# Altium schematic sheet BPSDSchematic.SchDoc
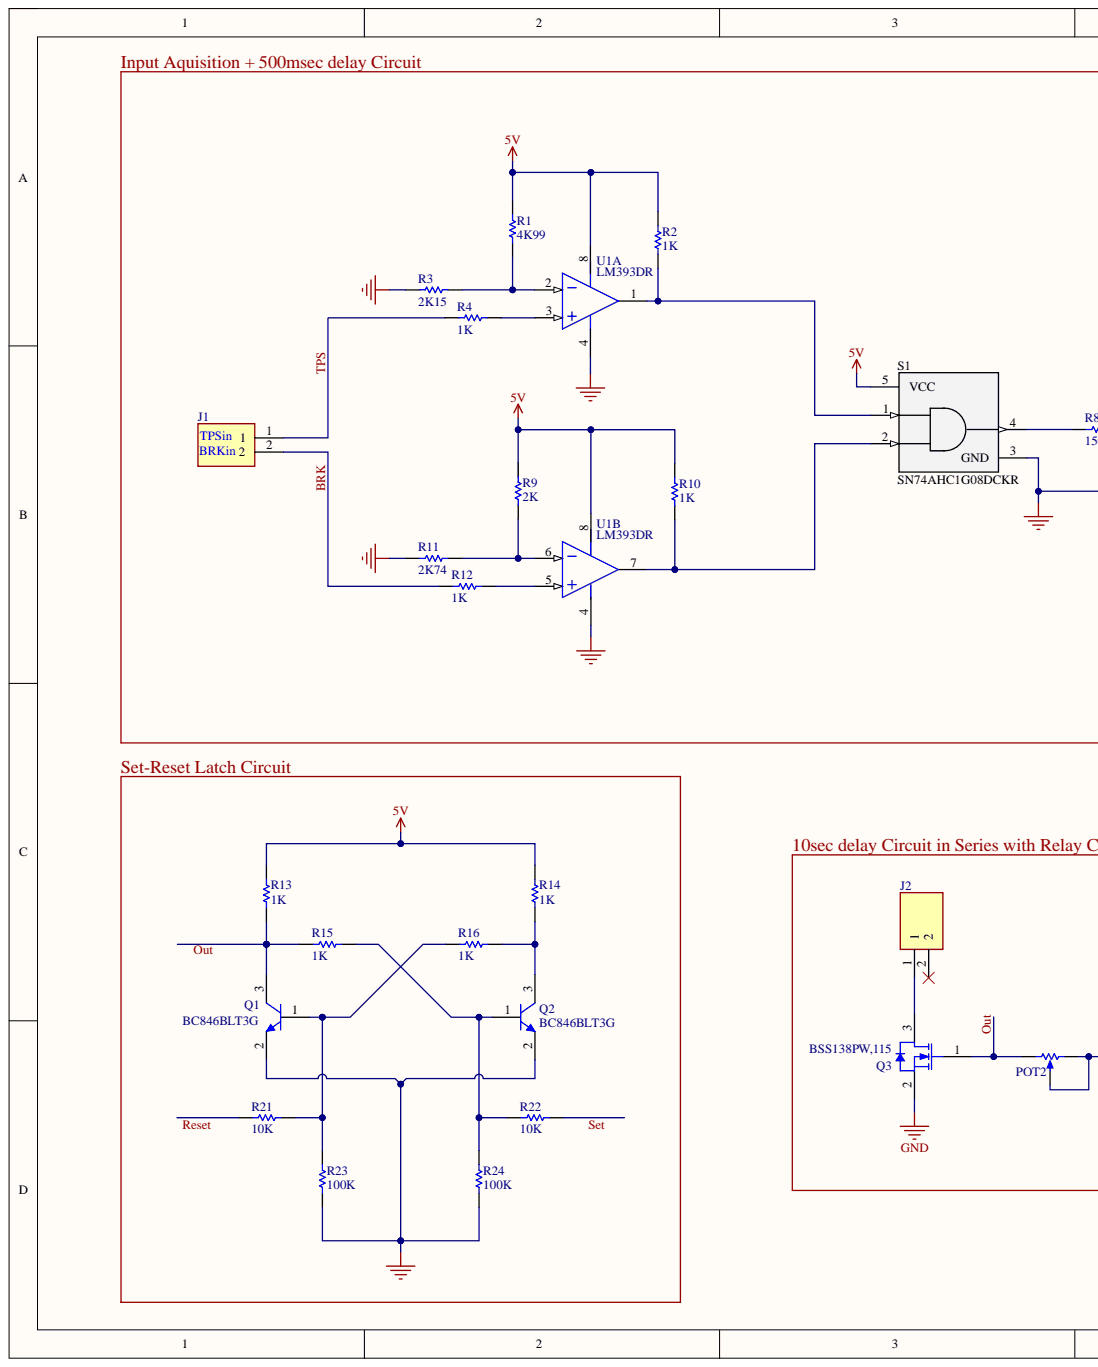
[diagram p0: BPSDSchematic.SchDoc, left]
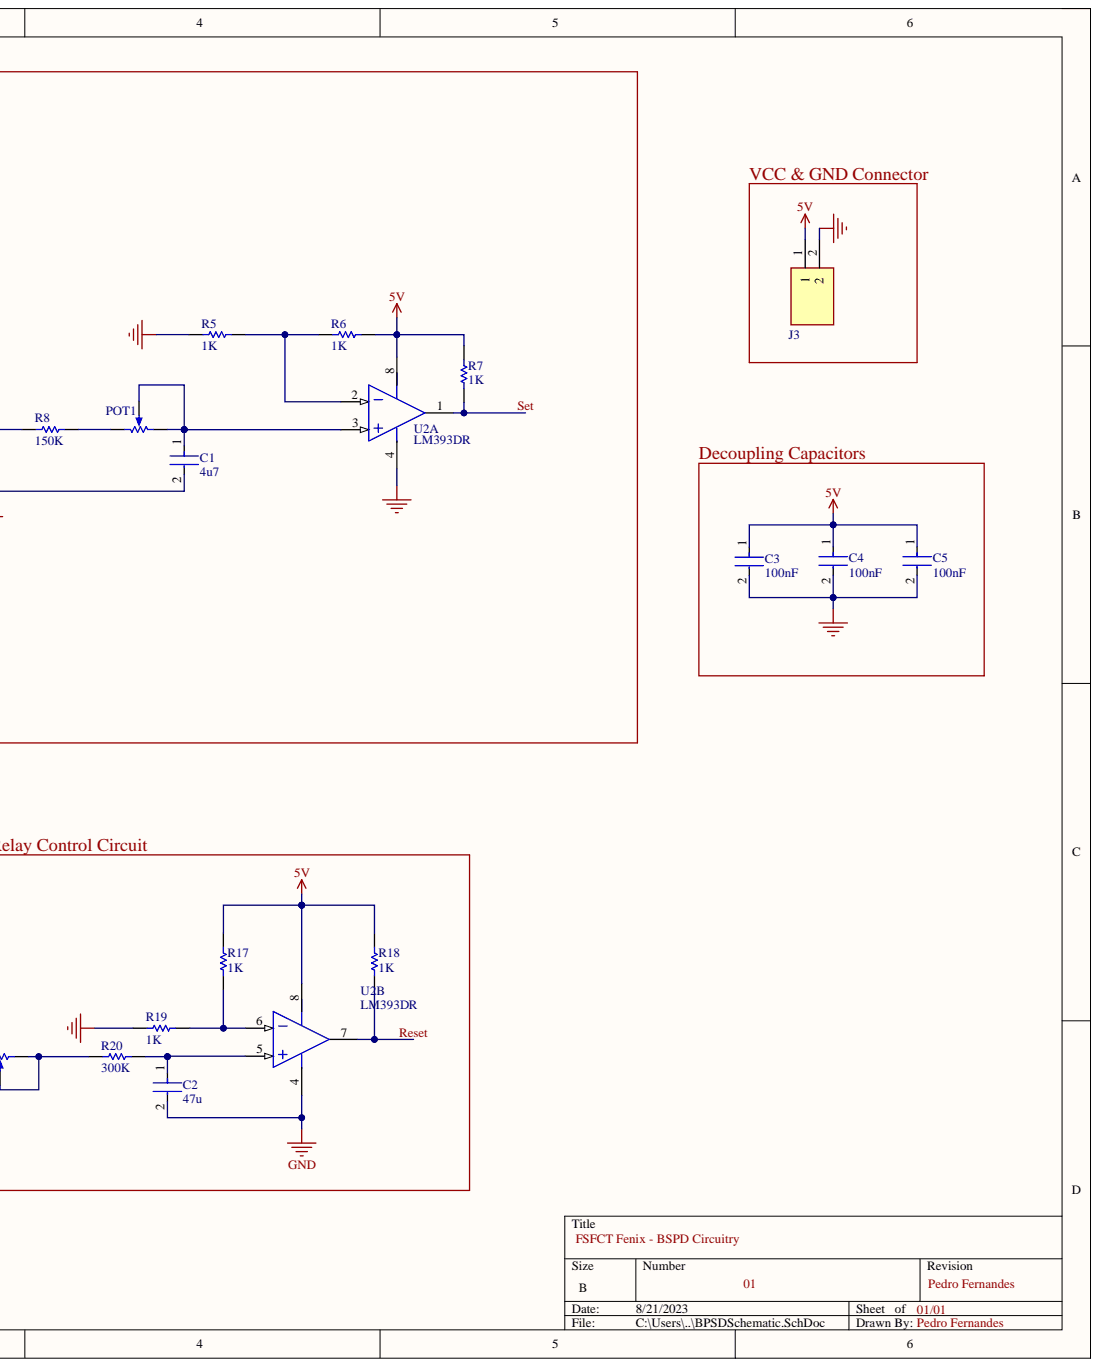
[diagram p1: BPSDSchematic.SchDoc, right]

## Parts
C1  4u7  [FP-CL10-IPC_C]
C2  47u  [CL32B476KQVVPNE]
C3  100nF  [FP-CL94-IPC_C]
C4  100nF  [FP-CL94-IPC_C]
C5  100nF  [FP-CL94-IPC_C]
J1  640445-2  [640445-2]  (value not drawn)
J2  640445-2  [640445-2]  (value not drawn)
J3  640445-2  [640445-2]  (value not drawn)
POT1  3362P-1-103LF  [BOUR-3362P]  (value not drawn)
POT2  3362P-1-103LF  [BOUR-3362P]  (value not drawn)
Q1  BC846BLT3G  [ONSC-SOT-23-3-318-08_V]
Q2  BC846BLT3G  [ONSC-SOT-23-3-318-08_V]
Q3  BSS138PW,115  [NXP-SOT323_M]
R1  4K99  [RC0603FR-074K99L]
R2  1K  [RC0603FR-071KL]
R3  2K15  [RESC0603(1608)_M]
R4  1K  [RC0603FR-071KL]
R5  1K  [RC0603FR-071KL]
R6  1K  [RC0603FR-071KL]
R7  1K  [RC0603FR-071KL]
R8  150K  [RC0603FR-07150KL]
R9  2K  [RC0603FR-072KL]
R10  1K  [RC0603FR-071KL]
R11  2K74  [RESC0603(1608)_N]
R12  1K  [RC0603FR-071KL]
R13  1K  [RC0603FR-071KL]
R14  1K  [RC0603FR-071KL]
R15  1K  [RC0603FR-071KL]
R16  1K  [RC0603FR-071KL]
R17  1K  [RC0603FR-071KL]
R18  1K  [RC0603FR-071KL]
R19  1K  [RC0603FR-071KL]
R20  300K  [RESC0603(1608)_M]
R21  10K  [RC0603FR-0710KL]
R22  10K  [RC0603FR-0710KL]
R23  100K  [RC0603FR-07100KL]
R24  100K  [RC0603FR-07100KL]
S1  SN74AHC1G08DCKR  [FP-DCK0005A-MFG]
U1  LM393DR  [LM393DR]  (parts A,B)
U2  LM393DR  [LM393DR]  (parts A,B)

## Nets
5V: C3.1 C4.1 C5.1 J3.1 R1.2 R2.2 R6.2 R7.2 R9.2 R10.2 R13.2 R14.2 R17.1 R18.1 S1.5(VCC) U1.8 U2.8
GND: C1.2 C2.2 C3.2 C4.2 C5.2 J3.2 Q1.2 Q2.2 Q3.2 R3.1 R5.1 R11.1 R19.1 R23.1 R24.1 S1.3(GND) U1.4 U2.4
BRK: J1.2 R12.1
Out: POT2.1 Q3.1
Reset: R18.2 R21.1 U2.7
Set: R7.1 R22.2 U2.1
TPS: J1.1 R4.1
NetC1_1: C1.1 POT1.2 POT1.3 U2.3
NetC2_1: C2.1 R20.2 U2.5
NetJ2_1: J2.1 Q3.3
NetPOT1_1: POT1.1 R8.2
NetPOT2_2: POT2.2 POT2.3 R20.1
NetQ1_1: Q1.1 R16.1 R21.2 R23.2
NetQ1_3: Q1.3 R13.1 R15.1
NetQ2_1: Q2.1 R15.2 R22.1 R24.2
NetQ2_3: Q2.3 R14.1 R16.2
NetR1_1: R1.1 R3.2 U1.2
NetR2_1: R2.1 S1.1 U1.1
NetR4_2: R4.2 U1.3
NetR5_2: R5.2 R6.1 U2.2
NetR8_1: R8.1 S1.4
NetR9_1: R9.1 R11.2 U1.6
NetR10_1: R10.1 S1.2 U1.7
NetR12_2: R12.2 U1.5
NetR17_2: R17.2 R19.2 U2.6

Not connected: J2 2

## Library data of the parts
C1 = CMP-2000-06096-2: CAP CER 4.7UF 6.3V X7R 0603; Manufacturer: Samsung; Manufacturer Part Number: CL10B475KQ8NQNC; Material: Ceramic; Temperature Coefficient: 15%; Tolerance: 10%; Automotive: No; Capacitance: 4.7uF; Category: Cap; Component Kind: Standard; ComponentLink2Description: Datasheet; ComponentLink2URL: http://www.samsungsem.com/kr/support/product-search/mlcc/__icsFiles/afieldfile/2018/07/24/CL10B475KQ8NQNC.pdf; Device Class L1: Passive Components; Device Class L2: Capacitors; Device Class L3: Ceramic Capacitors; Digikey Description: CAP CER 4.7UF 6.3V X7R 0603; Height: 0.95mm; Ipc Land Pattern Name: CAPC16080X80; Lead Free: Yes; Library Name: Altium Content Vault; Mouser Description: C Series 0402 4.7 uF 6.3 V ±20 % Tolerance X5R SMT Multilayer Ceramic Capacitor; Octopart: https://octopart.com/cl10b475kq8nqnc-samsung-24957976; Package: CL10; RoHS: TRUE; Temperature: -55°C to +125°C; Temperature Characteristic: X7R; Temperature Range High: +125°C; Temperature Range Low: -55°C; Value: 4.7uF; Voltage Rating: 6.3V
C2 = CL32B476KQVVPNE: CAP CER 47UF 6.3V X7R 0603; Component Kind: Standard; Library Name: BSPD.SchLib
C3-C5 = CMP-13271-000088-1: Cap Ceramic 100nF 6.3V X7R ±10% SMD 0402 +125°C Paper T/R; Capacitance: 100nF; Case Code (Imperial): 0402; Case Code (Metric): 1005; Case/Package: 0402; Component Kind: Standard; Depth: 0.5mm; Dielectric: X7R; Height: 0.5mm; Lead Free: Lead Free; Length: 1mm; Library Name: Altium Content Vault; Max Operating Temperature: 125°C; Min Operating Temperature: -55°C; Mount: Surface Mount; Packaging: Tape and Reel; Radiation Hardening: No; Thickness: 0.022inch; Tolerance: 10%; Voltage Rating: 6.3V; Voltage Rating (DC): 6.3V; Width: 0.02inch
POT1 POT2 = CMP-1747-00004-1: Square Trimpot(R) Trimming Potentiometer, 10 KOhm, 300 V, -55 to 125 degC, 3-Pin THD, RoHS, Tube; Power Rating: 1 W; Resistance: 50 mohm; Tolerance: 1%; Voltage Rating: 220 mV; Case Code (Imperial): 0612; Case Code (Metric): 1632; Case/Package: 1206; Component Kind: Standard; ComponentLink1Description: Manufacturer URL; ComponentLink1URL: http://www.bourns.com/; ComponentLink2Description: Datasheet; ComponentLink2URL: http://www.bourns.com/docs/Product-Datasheets/3362.pdf; DatasheetVersion: May-16; Height: 0.6 mm; Length: 3.2 mm; Library Name: Altium Content Vault; Max Operating Temperature: 125degC; Min Operating Temperature: -25degC; Mount: Surface Mount; Package Quantity: 5000; PackageDescription: 3-Pin Through Hole Device, Body 6.6 x 6.99 mm, Pitch 2.54 mm; PackageReference: 3326P; Packaging: Tape and Reel; Pins: 2; REACH SVHC: No SVHC; RoHSCompliant: True; Width: 1.6 mm
Q1 Q2 = CMP-1048-00780-1: General Purpose Transistor, NPN Silicon, 3-Pin SOT-23, Pb-Free, Tape and Reel; Manufacturer: On Semiconductor; fT Min (MHz): 100; IC Continuous (A): 0.1; Component Kind: Standard; ComponentLink1Description: Manufacturer URL; ComponentLink1URL: http://www.onsemi.com/; ComponentLink2Description: Datasheet; ComponentLink2URL: http://www.onsemi.cn/pub_link/Collateral/BC846ALT1-D.PDF; ComponentLink3Description: Package Specification; ComponentLink3URL: http://www.onsemi.cn/pub_link/Collateral/318-08.PDF; DatasheetVersion: Rev. 11; Library Name: Altium Content Vault; Mounting Technology: Surface Mount; PackageDescription: 3-Pin Small Outline Transistor, Body 2.92 x 1.3 mm, Pitch 0.95 mm; PackageReference: SOT-23-3-318-08; PackageVersion: Rev. AP, 11/2009; Packing: Tape and Reel; PartNumber: BC846BLT3G; Polarity: NPN; RoHS: TRUE
Q3 = CMP-1259-00109-1: N-Channel Trench MOSFET, 60 V, 0.32 A, -55 to 150 degC, 3-Pin SOT323, Tape and Reel; Manufacturer: NXP Semiconductors; Automotive Qualified: Y; Channel Type: N; ID [max] (A): 0.32; IDM [max] (A): 1.2; Packing: Tape & Reel; VDS [max] (V): 60; Code_JEITA: SC-70; Component Kind: Standard; ComponentLink1Description: Manufacturer URL; ComponentLink1URL: http://www.nxp.com/; ComponentLink2Description: Datasheet; ComponentLink2URL: http://www.nxp.com/documents/data_sheet/BSS138PW.pdf; ComponentLink3Description: Package Specification; ComponentLink3URL: http://www.nxp.com/documents/outline_drawing/sot323_po.pdf; DatasheetVersion: Rev. 1; Library Name: Altium Content Vault; Mounting Technology: Surface Mount; PackageDescription: 3-Pin Small Outline Transistor Package, Body 2 x 1.25 mm, Pitch 0.65 mm; PackageReference: SOT323; PackageVersion: 06-03-16, 03/2006; PartNumber: BSS138PW,115; VGSth [typ] (V): 1.2
R1 = RC0603FR-074K99L: 4K99 0.1W 1% 0603 (1608 Metric)  SMD; Component Kind: Standard; Library Name: BSPD.SchLib
R2 R4-R7 R10 R12-R19 = RC0603FR-071KL: 1K 0.1W 1% 0603 (1608 Metric)  SMD; Component Kind: Standard; Library Name: BSPD.SchLib
R3 = CMP-1012-00545-1: 2K15 0.1W 1% 0603 (1608 Metric)  SMD; Case-EIA: 0603; Case-Metric: 1608; Value: 2150.00 Ohm; Component Kind: Standard; Library Name: Altium Content Vault; Power: 0.1 W; Technology: SMT; Tolerance: 1 %
R8 = RC0603FR-07150KL: 150K 0.1W 1% 0603 (1608 Metric)  SMD; Component Kind: Standard; Library Name: BSPD.SchLib
R9 = RC0603FR-072KL: 2K 0.1W 1% 0603 (1608 Metric)  SMD; Component Kind: Standard; Library Name: BSPD.SchLib
R11 = CMP-1012-00558-1: 2K74 0.1W 1% 0603 (1608 Metric)  SMD; Case-EIA: 0603; Case-Metric: 1608; Value: 2740.00 Ohm; Component Kind: Standard; Library Name: Altium Content Vault; Power: 0.1 W; Technology: SMT; Tolerance: 1 %
R20 = CMP-1012-00788-1: 300K 0.1W 1% 0603 (1608 Metric)  SMD; Case-EIA: 0603; Case-Metric: 1608; Value: 300000.00 Ohm; Component Kind: Standard; Library Name: Altium Content Vault; Power: 0.1 W; Technology: SMT; Tolerance: 1 %
R21 R22 = RC0603FR-0710KL: 100K 0.1W 1% 0603 (1608 Metric)  SMD; Component Kind: Standard; Library Name: BSPD.SchLib
R23 R24 = RC0603FR-07100KL: 100K 0.1W 1% 0603 (1608 Metric)  SMD; Component Kind: Standard; Library Name: BSPD.SchLib
S1 = CMP-0859-00395-4: IC GATE AND 1CH 2-INP SC70-5; Manufacturer: Texas Instruments; Max Junction Temp: +150°C; Max Supply Voltage: 5.5V; Min Supply Voltage: 2V; Nominal Supply Current: 1uA; Number Of Circuits: 1; Number Of Inputs: 2; Propagation Delay: 5.8ns; Standoff Height: 0mm; Ambient Temperature Range High: +125°C; Ambient Temperature Range Low: -55°C; Automotive: No; Category: IC; Component Kind: Standard; ComponentLink2Description: Datasheet; ComponentLink2URL: https://www.ti.com/lit/ds/symlink/sn74ahc1g08.pdf; Device Class L1: Integrated Circuits (ICs); Device Class L2: Logic ICs; Device Class L3: Logic Gates; Digikey Description: IC GATE AND 1CH 2-INP SC70-5; Height: 1.1mm; Lead Free: Yes; Library Name: Altium Content Vault; Logic Function Desc: AND; Manufacturer Part Number: SN74AHC1G08DCKR; Mouser Description: IC: digital; Mounting: SMD; Case: SC70-5; Gate: AND; Se; Number Of Outputs: 1; Octopart: https://octopart.com/sn74ahc1g08dckr-texas+instruments-465512; Package: DCK0005A; RoHS: TRUE; Temperature: -55°C to +125°C; Temperature Range High: +125°C; Temperature Range Low: -55°C
J1-J3 = 640445-2: Component Kind: Standard; Library Name: BSPD.SchLib
U1 U2 = LM393DR: Component Kind: Standard; Library Name: BSPD.SchLib
